# Altium schematic sheet Stage2A_Buck_controller.SchDoc
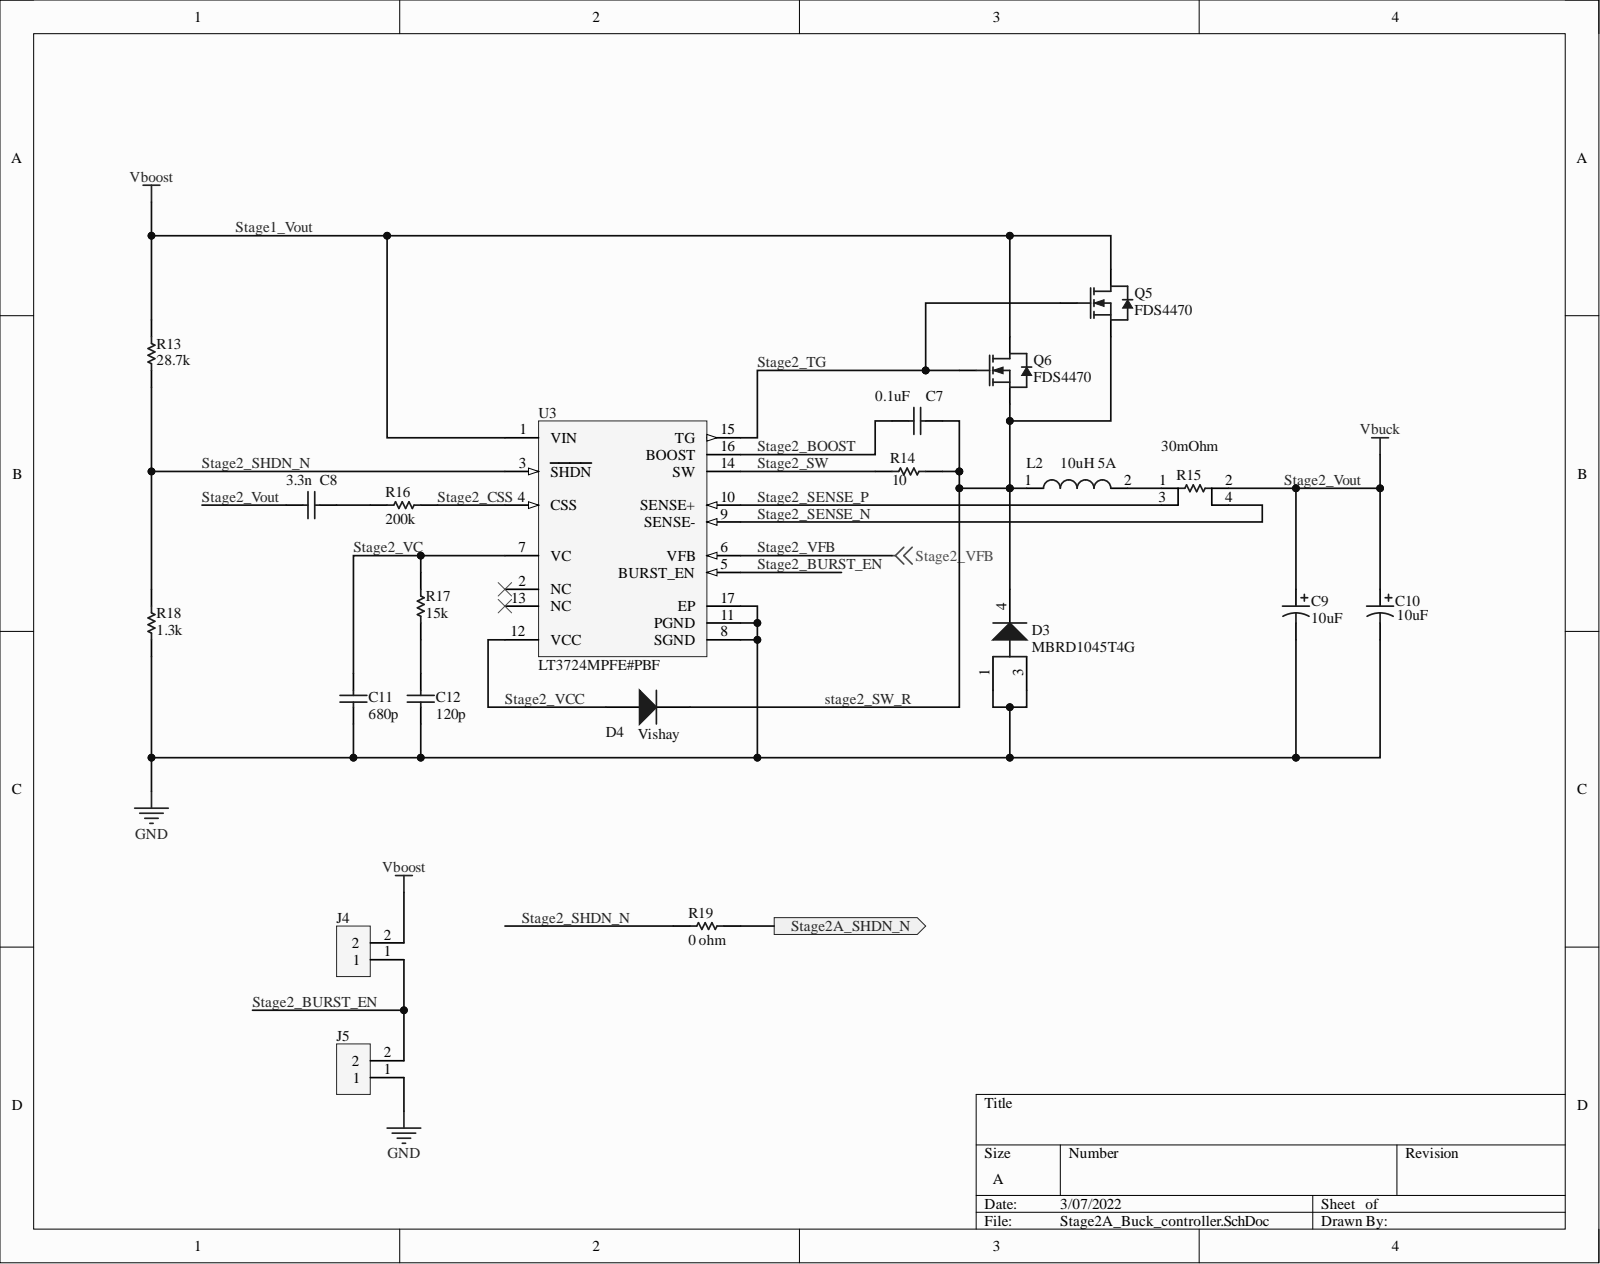
[diagram p0: Stage2A_Buck_controller.SchDoc]

## Parts
C7  0.1uF  [PCB-0002-00735-1]
C8  3.3n  [PCB-iub6dlq54ohgyg9g95v4-1]
C9  10uF  [PCB-45uljczbzgwzo9m7ql45-1]
C10  10uF  [PCB-45uljczbzgwzo9m7ql45-1]
C11  680p  [CAPC2013X145X48ML20T23]
C12  120p  [CAPC2013X145X48ML20T23]
D3  MBRD1045T4G  [ONSC-DPAK-3-369C-01_V]
D4  Vishay  [PCB-aq0vep24p5p345c98j9h-1]
J4  (no value)  [PCB-omgsbkhp90n7lpri88og-1]
J5  (no value)  [PCB-omgsbkhp90n7lpri88og-1]
L2  10uH 5A  [WE-PD-L]
Q5  FDS4470  [PCB-vam1no1ldi8601k0q85a-1]
Q6  FDS4470  [PCB-vam1no1ldi8601k0q85a-1]
R13  28.7k  [RESC2013X60X35LL10T20]
R14  10  [RESC2013X70X40LL20T20]
R15  30mOhm  [PCB-e4z1ou8eehx9zfei5nig-1]
R16  200k  [PCB-ec8bjsgds03v1bsrpylx-1]
R17  15k  [RESC2013X60X35LL10T20]
R18  1.3k  [RESC2013X60X30NL20T20]
R19  0 ohm  [RESC2013X60X35NL10T20]
U3  LT3724MPFE#PBF  [LT-FE-16-EPV-BC_M]

## Ports
Stage2A_SHDN_N

## Nets
GND: C9.2 C10.2 C11.1 C12.1 D3.1 D3.3 J5.1 R18.1 U3.8(SGND) U3.11(PGND) U3.17(EP)
Stage1_Vout: C7.2 D3.4 D4.1 J4.2 L2.1 Q5.2 Q5.3 Q6.2 Q6.3 R13.2 R14.2 U3.1(VIN)  [also labelled stage2_SW_R]
Stage2_BOOST: C7.1 U3.16(BOOST)
Stage2_BURST_EN: J4.1 J5.2 U3.5(BURST_EN)
Stage2_CSS: R16.2 U3.4(CSS)
Stage2_SENSE_N: R15.4 U3.9(SENSE-)
Stage2_SENSE_P: R15.3 U3.10(SENSE+)
Stage2_SHDN_N: R13.1 R18.2 R19.1 U3.3(SHDN)
Stage2_SW: R14.1 U3.14(SW)
Stage2_TG: Q5.1 Q6.1 U3.15(TG)
Stage2_VC: C11.2 R17.2 U3.7(VC)
Stage2_VCC: D4.2 U3.12(VCC)
Stage2_VFB: U3.6(VFB)
Stage2_Vout: C8.1 C9.1 C10.1 R15.2
Stage2A_SHDN_N: R19.2 ; port Stage2A_SHDN_N
NetC8_2: C8.2 R16.1
NetC12_2: C12.2 R17.1
NetL2_2: L2.2 R15.1

Not connected: U3 2(NC) 13(NC)

## Library data of the parts
C7 = CMP-126393-3: CAP CER 0.1UF 50V 10% X7R 0805; Manufacturer Part Number: 08055C104KAZ2A; Capacitance: 1e-07 F; Case/Package (SI): 2012; Dielectric Material: Ceramic Multilayer; Lead-Free Status: Lead Free; Voltage Rating (DC): 50.0 V; Capacitance Tolerance: ±10%; Case/Package: 0805; Component Kind: Standard; Dielectric Characteristic: X7R; Library Name: My Personal Space; Mounting Style: Surface Mount; Number of Pins: 2; Octopart Url: https://octopart.com/08055c104kaz2a-avx+interconnect+%2F+elco-195624; OctopartID: aa35633d737b75f2; REACH SVHC Compliance: No SVHC; RoHS: Compliant; Size-Height: 0.00094 m; Size-Length: 0.00201 m; Size-Width: 0.00125 m
C8 = CMP-44648f345bea9ba3-1: Multilayer Ceramic Capacitor, CC Series, 3300 pF, - 10%, X7R, 50 V, 0805 [2012 Metric]; Manufacturer Part Number: CC0805KRX7R9BB332; Capacitance: 3.30 nF; Case/Package (SI): 2012; Insulation Resistance: 100 GΩ; Lead-Free Status: Lead Free; Voltage Rating (DC): 50.0 V; Capacitance Tolerance: ±10%; Case/Package: 0805; Component Kind: Standard; Dielectric Characteristic: X7R; Dielectric Material: Ceramic; Halogen Free Status: Halogen Free; Library Name: My Personal Space; Mounting Style: Surface Mount; Number of Pins: 2; Octopart URL: http://octopart.com/cc0805krx7r9bb332-yageo-39467752; OctopartID: 44648f345bea9ba3; Packaging: Cut Tape (CT); REACH SVHC Compliance: No SVHC; RoHS: Compliant; Size-Height: 940 µm; Size-Length: 2.01 mm; Size-Width: 1.25 mm
C9 C10 = CMP-7610bd40367da9d7-6: Capacitor; Aluminum Electrolytic; Fc Radial Elec Cap 10uF 50V; Manufacturer Part Number: EEU-FC1H100L; Capacitance: 10.0 µF; Capacitance Tolerance: ±20%; Lead-Free Status: Lead Free; Voltage Rating (DC): 50.0 V; Component Kind: Standard; Dielectric Material: Aluminum Electrolytic; Library Name: My Personal Space; Lifecycle Status: Not Listed by Manufacturer; Mounting Style: Through Hole; Number of Pins: 2; Octopart URL: https://octopart.com/eeu-fc1h100l-panasonic-39478539; OctopartID: 7610bd40367da9d7; Operating Temperature: 105 °C (max); Packaging: Bulk; REACH SVHC Compliance: No SVHC; RoHS: Compliant; Size-Diameter: 5.00 mm; Size-Height: 11.0 mm; Size-Length: 11.0 mm
C11 C12 = CMP-3554732-2: Multilayer Ceramic Capacitors MLCC - SMD/SMT 0805 120pF 250V C0G 10% HI FREQ; Manufacturer Part Number: VJ0805D121KXPAJ; Capacitance: 1.2e-10 F; Dielectric Characteristic: C0G/NP0; Lead-Free Status: Lead Free; Voltage Rating (DC): 250.0 V; Capacitance Tolerance: ±10%; Component Kind: Standard; Library Name: My Personal Space; Octopart Url: https://octopart.com/vj0805d121kxpaj-vishay-45210306; OctopartID: d2bb19002d1f0d7c; Packaging: Cut Tape (CT); RoHS: Compliant
D3 = CMP-10976408-2: SWITCHMODE(TM) Schottky Power Rectifier, 3-Pin DPAK, Pb-Free, Tape and Reel; Current Rating: 10.0 A; Lead-Free Status: Lead Free; Polarity: Standard; Voltage Rating (DC): 45.0 V; Case/Package: DPAK; Component Kind: Standard; Halogen Free Status: Halogen Free; Library Name: My Personal Space; Lifecycle Status: Active; Manufacturer Part Number: MBRD1045T4G; Mounting Style: Surface Mount; Number of Pins: 3; Octopart Url: https://octopart.com/mbrd1045t4g-on+semiconductor-1229353; OctopartID: f02c393417632feb; Packaging: Tape; REACH SVHC Compliance: No SVHC; RoHS: Compliant
D4 = CMP-93ae58ff32594c69-2: S1G Series 1 A 400 V Surface Mount Glass Passivated Rectifier - DO-214AC; Manufacturer Part Number: S1G-E3/61T; Capacitance: 12.0 pF; Current Rating: 1.00 A; Lead-Free Status: Lead Free; Output Current: 1.00 A (max); Polarity: Standard; Voltage Rating (DC): 400 V; Component Kind: Standard; Library Name: My Personal Space; Mounting Style: Surface Mount; Number of Pins: 2; Octopart URL: http://octopart.com/s1g-e3%2F61t-vishay-39552145; OctopartID: 93ae58ff32594c69; Packaging: Cut Tape (CT), Tape & Reel (TR); RoHS: Compliant
J4 J5 = CMP-748fbcb73d6bab48-30: Pitch 2.54 mm, Single Row, 2pins; Component Kind: Standard; Library Name: My Personal Space
L2 = CMP-912551-2: SMD-Shielded Power Inductor WE-PD, L = 10.0 µH; Manufacturer Part Number: 74477110; Inductance: 1e-05 H; Resistance: 0.018 Ω; Resonant Frequency: 21500000.0 Hz; Component Kind: Standard; Library Name: My Personal Space; Number of Pins: 2; Octopart Url: https://octopart.com/74477110-w%C3%BCrth+elektronik-8917130; OctopartID: 4fd586577a399012; Packaging: Cut Tape (CT); REACH SVHC Compliance: No SVHC; RoHS: Compliant; Self Resonant Frequency: 21500000.0 Hz
Q5 Q6 = CMP-cab003bfccd71577-2: FDS4470 Series 40 V 9 mOhm Surface Mount N-Channel PowerTrench Mosfet - SOIC-8; Breakdown Voltage (Drain to Source): -100.0 V; Continuous Drain Current (Ids): 12.5 A; Drain to Source Resistance (on) (Rds): 0.009 Ω; Drain to Source Voltage (Vds): 40.0 V; Gate Charge: 4.5e-08 C; Input Capacitance: 2.659e-09 F; Lead-Free Status: Lead Free; Power Dissipation: 2.5 W; Rise Time: 1.2e-08 s; Case/Package: SOIC; Component Kind: Standard; Current Rating: 12.5 A; Library Name: My Personal Space; Lifecycle Status: Active; Manufacturer Part Number: FDS4470; Mounting Style: Surface Mount; Number of Pins: 8; Octopart URL: http://octopart.com/fds4470-fairchild+semiconductor-336641; OctopartID: cab003bfccd71577; Packaging: Tape; Polarity: N-Channel; REACH SVHC Compliance: No SVHC; RoHS: Compliant; Voltage Rating (DC): 40.0 V
R13 = CMP-1854552-2: RES SMD 287K OHM 1% 1/8W 0805; Manufacturer Part Number: RC0805FR-07287KL; Case/Package (SI): 2012; Lead-Free Status: Lead Free; Power Rating: 0.125 W; Resistance: 287000.0 Ω; Voltage Rating (DC): 150.0 V; Case/Package: 0805; Component Kind: Standard; Library Name: My Personal Space; Mounting Style: Surface Mount; Octopart Url: https://octopart.com/rc0805fr-07287kl-yageo-1169350; OctopartID: 0d3bc420ff9bd2fc; Resistance Tolerance: ±1%; RoHS: Compliant; Size-Height: 0.0005 m; Size-Length: 0.002 m; Size-Width: 0.00125 m
R14 = CMP-3653897-2: Thick Film Resistors - SMD 0805 10ohms 0.25W 5% Tol; Manufacturer Part Number: ERJ-P06J100V; Case/Package (SI): 2012; Lead-Free Status: Lead Free; Power Rating: 0.5 W; Resistance: 10.0 Ω; Voltage Rating (DC): 400.0 V; Component Kind: Standard; Library Name: My Personal Space; Lifecycle Status: Not Listed by Manufacturer; Mounting Style: Surface Mount; Octopart Url: https://octopart.com/erj-p06j100v-panasonic-39858980; OctopartID: e2332959f4713df4; Resistance Tolerance: ±5%; RoHS: Compliant; Size-Height: 0.0006 m; Size-Length: 0.002 m; Size-Width: 0.00125 m
R15 = CMP-41418819abb09e86-1: Res Metal Alloy 1206 0.03 Ohm 0.5% 0.5W(1/2W) ±50ppm/C Epoxy Resin Pad SMD T/R; Manufacturer Part Number: LVK12R030DER; Case/Package (SI): 3216; Power Rating: 500 mW; Resistance: 30.0 mΩ; Temperature Coefficient: -50.0 ppm/°C to 50.0 ppm/°C; Case/Package: 3216, 1206; Component Kind: Standard; Library Name: My Personal Space; Mounting Style: Surface Mount; Number of Pins: 2; Octopart URL: https://octopart.com/lvk12r030der-ohmite-5427095; OctopartID: 41418819abb09e86; Operating Temperature: -40.0 °C to 125 °C; Packaging: Tape & Reel (TR), Cut Tape (CT); Resistance Tolerance: ±0.5%; RoHS: Compliant
R16 = CMP-009dc8cdd678df5a-1: Res Thin Film 0805 200K Ohm 0.1% 1/10W ±10ppm/°C Molded SMD SMD Paper T/R; Manufacturer Part Number: RN73C2A200KBTDF; Case/Package (SI): 2012; ELV Compliant: Compliant; Power Rating: 100 mW; Resistance: 200 kΩ; Temperature Coefficient: -10.0 ppm/°C to 10.0 ppm/°C; Voltage Rating (DC): 100 V; Case/Package: 2012, 0805; Component Kind: Standard; Library Name: My Personal Space; Lifecycle Status: Active; Mounting Style: Surface Mount; Octopart URL: https://octopart.com/rn73c2a200kbtdf-te+connectivity-22737795; OctopartID: 009dc8cdd678df5a; Packaging: Tape & Reel (TR); Resistance Tolerance: ±0.1%; RoHS: Compliant
R17 = CMP-1875647-2: RES SMD 38.3K OHM 1% 1/8W 0805; Manufacturer Part Number: RC0805FR-0738K3L; Case/Package (SI): 2012; Lead-Free Status: Lead Free; Power Rating: 0.125 W; Resistance: 38300.0 Ω; Voltage Rating (DC): 150.0 V; Case/Package: 0805; Component Kind: Standard; Library Name: My Personal Space; Mounting Style: Surface Mount; Octopart Url: https://octopart.com/rc0805fr-0738k3l-yageo-1177806; OctopartID: 2daae4b1f002f26d; Resistance Tolerance: ±1%; RoHS: Compliant; Size-Height: 0.0005 m; Size-Length: 0.002 m; Size-Width: 0.00125 m
R18 = CMP-3670361-2: Thick Film Resistors - SMD 0.25W 1.3Kohm 1% 200ppm    Learn More; Manufacturer Part Number: SG73P2ATTD1301F; Case/Package (SI): 2012; Resistance: 1300.0 Ω; Temperature Coefficient: 200.0 ppm/°C; Voltage Rating (DC): 150.0 V; Component Kind: Standard; Library Name: My Personal Space; Mounting Style: Surface Mount; Number of Pins: 2; Octopart Url: https://octopart.com/sg73p2attd1301f-koa+speer-7748804; OctopartID: f80e3172e1ac27c9; Power Rating: 0.25 W; Resistance Tolerance: ±1%; RoHS: Compliant
R19 = CMP-5eab975428dd816c-2: Res Thick Film 0805 0 Ohm Jumper Molded SMD T/R; Manufacturer Part Number: CRG0805ZR; Case/Package (SI): 2012; ELV Compliant: Compliant; Power Rating: 125 mW; Resistance: 0.00 Ω; Case/Package: 0805; Component Kind: Standard; Library Name: My Personal Space; Lifecycle Status: Active; Mounting Style: Surface Mount; Octopart URL: https://octopart.com/crg0805zr-te+connectivity-16077584; OctopartID: 5eab975428dd816c; Packaging: Tape & Reel (TR); RoHS: Compliant
U3 = CMP-1478355-2: High Voltage, Current Mode Switching Regulator Controller, 16-pin SOP (FE-16-EPV-BC), -55 to 125 degC, Pb-Free; Lead-Free Status: Contains Lead; Component Kind: Standard; Library Name: My Personal Space; Manufacturer Part Number: LT3724MPFE#PBF; Octopart Url: https://octopart.com/lt3724mpfe%23pbf-linear+technology-12454061; OctopartID: 961f5fabbbd449d8; RoHS: Compliant
